# Altium schematic sheet cpu.SchDoc(cpu)
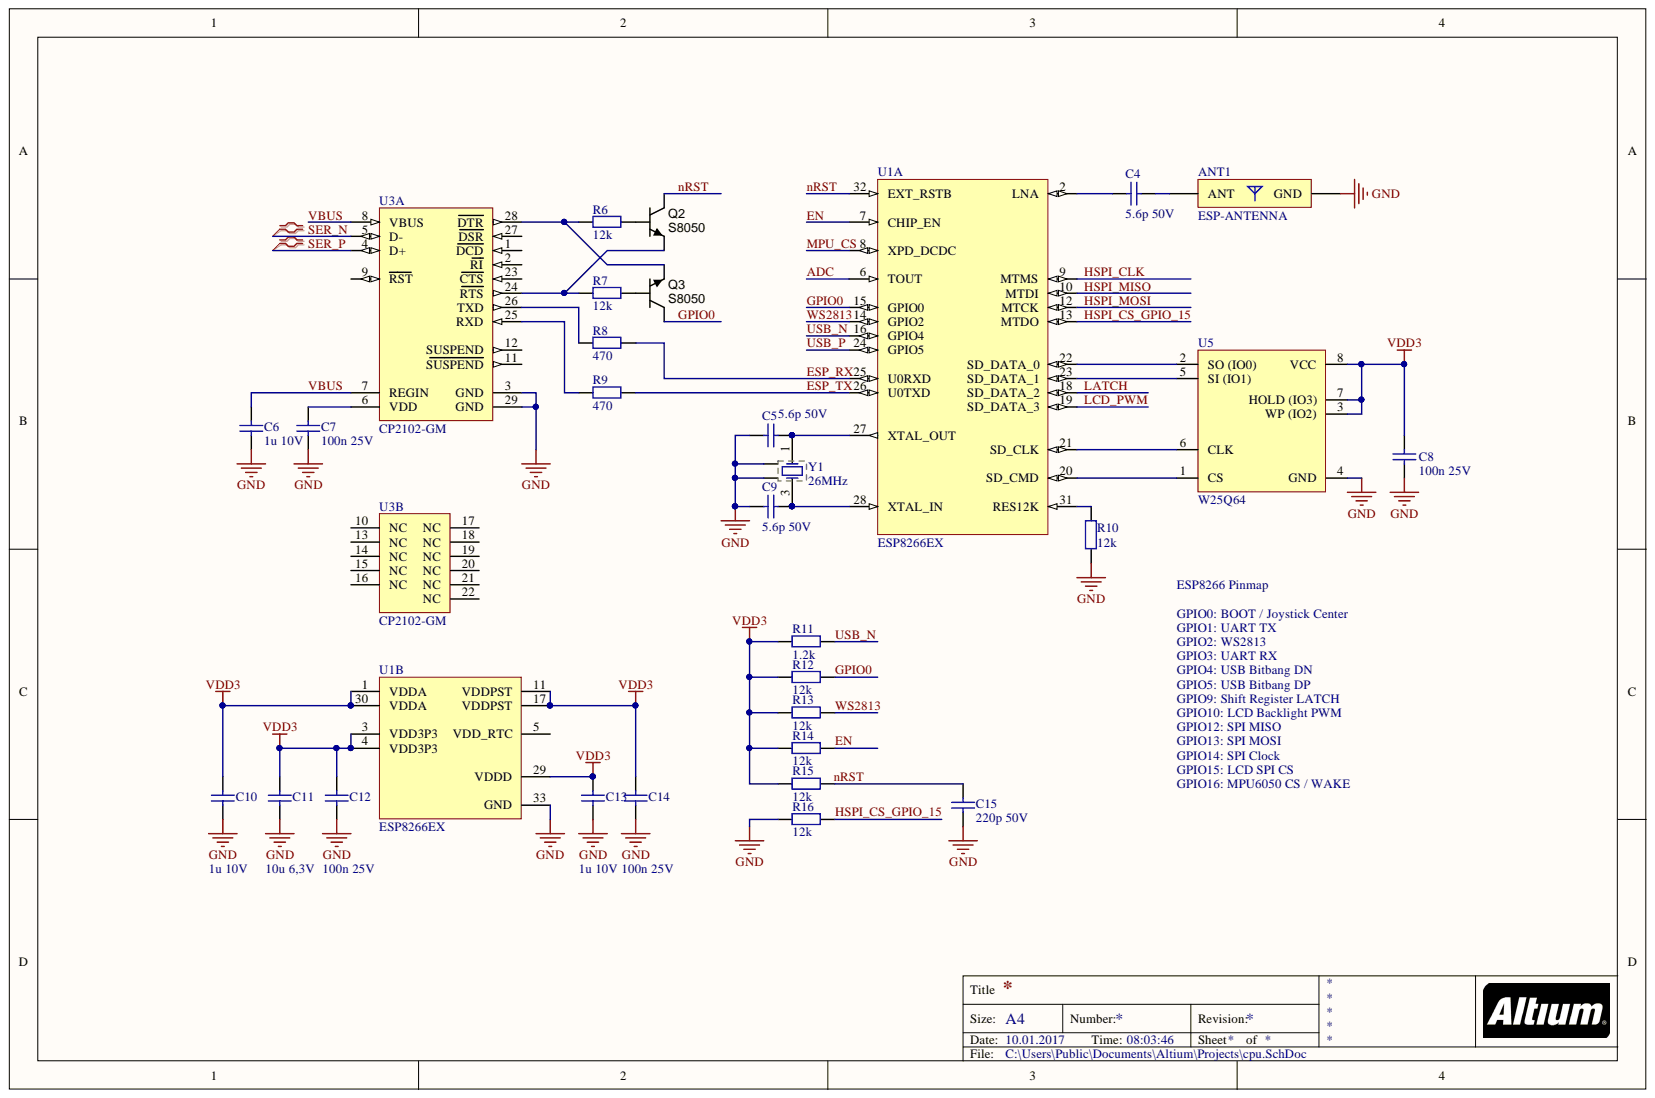
[diagram p0: cpu.SchDoc]

## Parts
ANT1  ESP-ANTENNA  [ESP8266_ANT]
C4  5.6p 50V  [C-0603-M]
C5  5.6p 50V  [C-0603-M]
C6  1u 10V  [C-0603-M]
C7  100n 25V  [C-0603-M]
C8  100n 25V  [C-0603-M]
C9  5.6p 50V  [C-0603-M]
C10  1u 10V  [C-0603-M]
C11  10u 6,3V  [C-0603-H]
C12  100n 25V  [C-0603-M]
C13  1u 10V  [C-0603-M]
C14  100n 25V  [C-0603-M]
C15  220p 50V  [C-0603-M]
Q2  Manufacturer Part Number 1: S8050  [SOT23-3]  (hidden comment: NPN_MMBT3904)
Q3  Manufacturer Part Number 1: S8050  [SOT23-3]  (hidden comment: NPN_MMBT3904)
R6  12k  [R-0603-M]
R7  12k  [R-0603-M]
R8  470  [R-0603-M]
R9  470  [R-0603-M]
R10  12k  [R-0603-M]
R11  1.2k  [R-0603-M]
R12  12k  [R-0603-M]
R13  12k  [R-0603-M]
R14  12k  [R-0603-M]
R15  12k  [R-0603-M]
R16  12k  [R-0603-M]
U1  ESP8266EX  [QFN32-5x5mm]  (parts A,B)
U3  CP2102-GM  [SLAB-QFN-28-3125x3125TP_M]  (parts A,B)
U5  W25Q64  [SOIC-8]
Y1  26MHz  [3225]

## Nets
GND: ANT1.2 C5.1 C6.1 C7.1 C8.1 C9.1 C10.1 C11.1 C12.1 C13.1 C14.1 C15.1 R10.2 R16.1 U1.33(GND) U3.3(GND) U3.29(GND) U5.4(GND) Y1.2 Y1.4
VDD3: C8.2 C10.2 C11.2 C12.2 C13.2 C14.2 R11.2 R12.1 R13.1 R14.1 R15.1 U1.1(VDDA) U1.3(VDD3P3) U1.4(VDD3P3) U1.11(VDDPST) U1.17(VDDPST) U1.29(VDDD) U1.30(VDDA) U5.3(WP_(IO2)) U5.7(HOLD_(IO3)) U5.8(VCC)
ADC: U1.6(TOUT)
EN: R14.2 U1.7(CHIP_EN)
ESP_RX: R8.2 U1.25(U0RXD)
ESP_TX: R9.2 U1.26(U0TXD)
GPIO0: Q3.3 R12.2 U1.15(GPIO0)
HSPI_CLK: U1.9(MTMS)
HSPI_CS_GPIO_15: R16.2 U1.13(MTDO)
HSPI_MISO: U1.10(MTDI)
HSPI_MOSI: U1.12(MTCK)
LATCH: U1.18(SD_DATA_2)
LCD_PWM: U1.19(SD_DATA_3)
MPU_CS: U1.8(XPD_DCDC)
NRST: Q2.3 U1.32(EXT_RSTB)
SER_N: U3.5(D-)
SER_P: U3.4(D+)
USB_N: R11.1 U1.16(GPIO4)
USB_P: U1.24(GPIO5)
VBUS: C6.2 U3.7(REGIN) U3.8(VBUS)
WS2813: R13.2 U1.14(GPIO2)
NetANT1_1: ANT1.1 C4.2
NetC4_1: C4.1 U1.2(LNA)
NetC5_2: C5.2 U1.27(XTAL_OUT) Y1.1
NetC7_2: C7.2 U3.6(VDD)
NetC9_2: C9.2 U1.28(XTAL_IN) Y1.3
NetC15_2: C15.2 R15.2
NetQ2_1: Q2.1 R6.2
NetQ2_2: Q2.2 R7.1 U3.24(RTS)
NetQ3_1: Q3.1 R7.2
NetQ3_2: Q3.2 R6.1 U3.28(DTR)
NetR8_1: R8.1 U3.26(TXD)
NetR9_1: R9.1 U3.25(RXD)
NetR10_1: R10.1 U1.31(RES12K)
NetU1_20: U1.20(SD_CMD) U5.1(CS)
NetU1_21: U1.21(SD_CLK) U5.6(CLK)
NetU1_22: U1.22(SD_DATA_0) U5.2(SO_(IO0))
NetU1_23: U1.23(SD_DATA_1) U5.5(SI_(IO1))

Not connected: U1 5(VDD_RTC); U3 1(DCD) 2(RI) 9(RST) 10(NC) 11(SUSPEND) 12(SUSPEND) 13(NC) 14(NC) 15(NC) 16(NC) 17(NC) 18(NC) 19(NC) 20(NC) 21(NC) 22(NC) 23(CTS) 27(DSR)

## Library data of the parts
ANT1 = ESP-ANT: Component Kind: Standard; Library Name: advanced.SchLib
C4 C5 C9 = C-0603-5p6-50V: Capacitor 5.6pF 50V; Component Kind: Standard; Library Name: Passives.IntLib; Supplier 1: Mouser; Supplier Part Number 1: 80-C0603C569C5GACTM
C6 C10 C13 = C-0603-1u-10V: Capacitor 1uF 10V; Component Kind: Standard; Library Name: Passives.IntLib; Supplier 1: Mouser; Supplier Part Number 1: 80-C0603C105K8P
C7 C8 C12 C14 = C-0603-100n-25V: Capacitor 100n 25V; Component Kind: Standard; Library Name: Passives.IntLib; Supplier 1: Mouser; Supplier Part Number 1: 80-C0603C104K3R
C11 = C-0603-10u-6,3V: Capacitor 10uF 6,3V; Component Kind: Standard; Library Name: Passives.IntLib; Supplier 1: Mouser; Supplier Part Number 1: 80-C0603C106M9P
C15 = C-0603-220p-50V: Capacitor 220p 50V; Component Kind: Standard; Library Name: Passives.IntLib; Supplier 1: Mouser; Supplier Part Number 1: 80-C0603C221F5GAC
Q2 Q3 = NPN_MMBT3904: Transistor SMD NPN 40V 0.2A 250mW SOT-23; Manufacturer 1: Fairchild Semiconductor; Material: Si; Ratings: 40V; 200mA; 250mW; SCDType: source; Component Kind: Standard; ComponentLink1Description: http://www.fairchildsemi.com/ds/MM/MMBT3904.pdf; ComponentLink1URL: http://www.fairchildsemi.com/ds/MM/MMBT3904.pdf; ComponentLink2Description: http://www.nxp.com/documents/data_sheet/PMBT3904.pdf; ComponentLink2URL: http://www.nxp.com/documents/data_sheet/PMBT3904.pdf; Library Name: PBL - Transistors.SCHLIB; Manufacturer 2: NXP Semiconductors; Manufacturer Part Number 2: PMBT3904,215; Mounting Type: Surface Mount; Package / Case: SOT-23; Supplier 1: Digi-Key; Supplier 2: Digi-Key; Supplier Part Number 1: MMBT3904FSCT-ND; Supplier Part Number 2: 568-1741-1-ND; Transistor Type: NPN
R6 R7 R10 R12-R16 = R-0603-12k: Resistor 12k; Component Kind: Standard; Library Name: Passives.IntLib; Supplier 1: Mouser; Supplier Part Number 1: 71-CRCW0603-12K-E3
R8 R9 = R-0603-470: Resistor 470; Component Kind: Standard; Library Name: Passives.IntLib; Supplier 1: Mouser; Supplier Part Number 1: 71-CRCW0603-470K-E3
R11 = R-0603-1k2: Resistor 1.2k; Component Kind: Standard; Library Name: Passives.IntLib
U3 = CMP-0511-00012-1: Single-Chip USB to UART Bridge, 1024 Bytes EEPROM, -40 to 85 degC, 28-pin QFN, Tube; Manufacturer: Silicon Labs; Internal Oscillator: TRUE; Sub-Family: USB Bridges; Code_IPC: J-STD-020C; Code_JEDEC: MO-220; Component Kind: Standard; ComponentLink1Description: Manufacturer URL; ComponentLink1URL: http://www.silabs.com/; ComponentLink2Description: Datasheet; ComponentLink2URL: http://www.silabs.com/Support%20Documents/TechnicalDocs/CP2102-9.pdf; ComponentLink3Description: Package Specification; ComponentLink3URL: http://www.silabs.com/Support%20Documents/TechnicalDocs/C8051T61x.pdf; DatasheetVersion: Rev. 1.5; EEPROM: 1024 Bytes; Library Name: Altium Content Vault; Mounting Technology: Surface Mount; PackageDescription: 28-Pin Quad-Flat No-Leads Package, Body 5 x 5 mm, Pitch 0.5 mm; PackageReference: QFN-28; PartId: 1; PartNumber: CP2102-GM
U5 = W25Q64: 8MB / 64Mib Flash; Component Kind: Standard; Library Name: advanced.IntLib
Y1 = 3225-26MHz: Component Kind: Standard; Library Name: advanced.SchLib
U1 = ESP8266EX: Component Kind: Standard; Description: ESP8266EX; Library Name: advanced.SchLib
